# Altium schematic sheet Main.SchDot(Main)
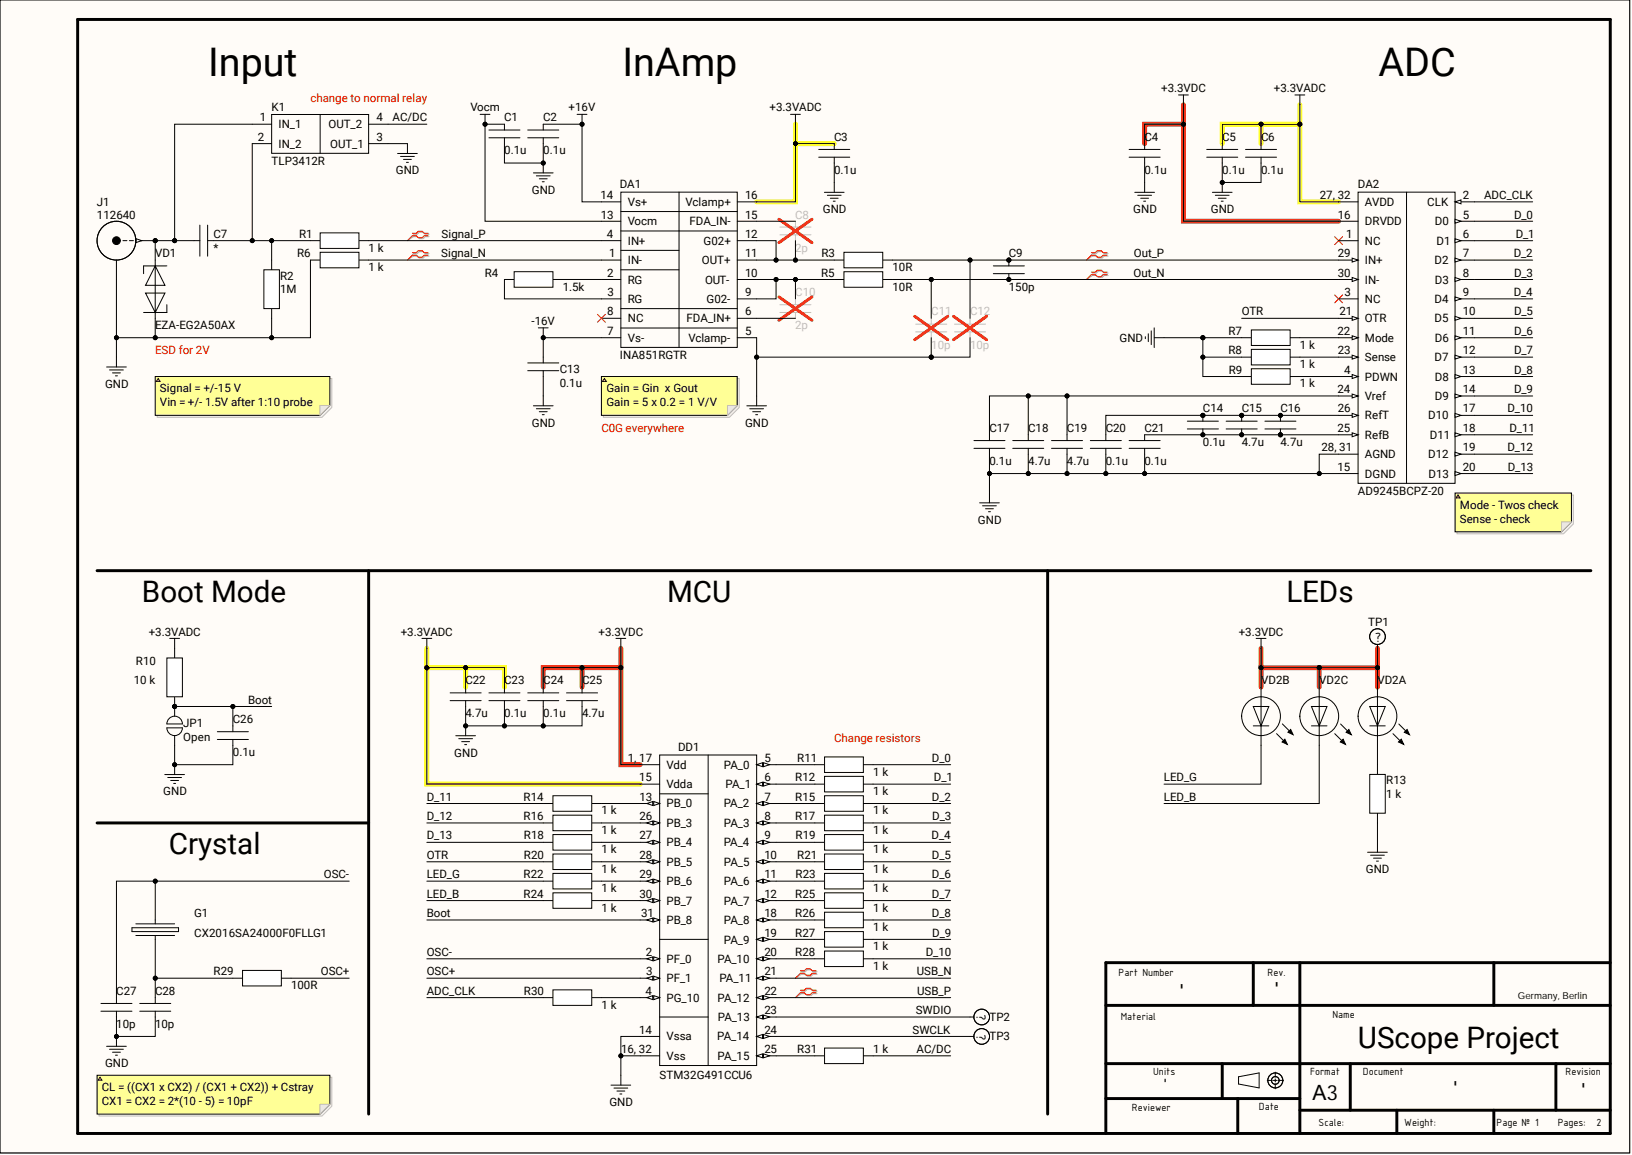
[diagram p0: Main.SchDot(Main)]

## Parts
C1  0.1u  [C0402]
C2  0.1u  [C0402]
C3  0.1u  [C0402]
C4  0.1u  [C0402]
C5  0.1u  [C0402]
C6  0.1u  [C0402]
C7  (no value)  [C0402]
C8  2p  [C0402]
C9  150p  [C0402]
C10  2p  [C0402]
C11  10p  [C0402]
C12  10p  [C0402]
C13  0.1u  [C0402]
C14  0.1u  [C0402]
C15  4.7u  [C0402]
C16  4.7u  [C0402]
C17  0.1u  [C0402]
C18  4.7u  [C0402]
C19  4.7u  [C0402]
C20  0.1u  [C0402]
C21  0.1u  [C0402]
C22  4.7u  [C0402]
C23  0.1u  [C0402]
C24  0.1u  [C0402]
C25  4.7u  [C0402]
C26  0.1u  [C0402]
C27  10p  [C0402]
C28  10p  [C0402]
DA1  INA851RGTR  [VQFN_16_INA851]
DA2  AD9245BCPZ-20  [LFCSP-32_AD9245]
DD1  STM32G491CCU6  [UFQFPN-32]
G1  CX2016SA24000F0FLLG1  [0806 (2016 metric)]
J1  112640  [112640]
JP1  Open  [Solder Bridge Jumper]
K1  TLP3412R  [SON-4]
R1  1 k  [R0402]
R2  1M  [R0402]
R3  10R  [R0402]
R4  1.5k  [R0402]
R5  10R  [R0402]
R6  1 k  [R0402]
R7  1 k  [R0402]
R8  1 k  [R0402]
R9  1 k  [R0402]
R10  10 k  [R0402]
R11  1 k  [R0402]
R12  1 k  [R0402]
R13  1 k  [R0402]
R14  1 k  [R0402]
R15  1 k  [R0402]
R16  1 k  [R0402]
R17  1 k  [R0402]
R18  1 k  [R0402]
R19  1 k  [R0402]
R20  1 k  [R0402]
R21  1 k  [R0402]
R22  1 k  [R0402]
R23  1 k  [R0402]
R24  1 k  [R0402]
R25  1 k  [R0402]
R26  1 k  [R0402]
R27  1 k  [R0402]
R28  1 k  [R0402]
R29  100R  [R0402]
R30  1 k  [R0402]
R31  1 k  [R0402]
TP1  5117  [Micro_test_pad]  (value not drawn)
TP2  5117  [Micro_test_pad]  (value not drawn)
TP3  5117  [Micro_test_pad]  (value not drawn)
VD1  EZA-EG2A50AX  [R0402]
VD2  150044M155260  [0404(RGB LED)]  (value not drawn)  (parts A,B,C)

## Nets
+3.3VADC: C3.2 C5.2 C6.2 C22.1 C23.1 DA1.16(Vclamp+) DA2.27, 32(AVDD) DD1.15(Vdda) R10.1
+3.3VDC: C4.2 C24.1 C25.1 DA2.16(DRVDD) DD1.1, 17(Vdd) TP1.1 VD2.1
+16V: C2.2 DA1.14(Vs+)
-16V: C13.2 DA1.7(Vs-)
GND: C1.1 C2.1 C3.1 C4.1 C5.1 C6.1 C11.2 C12.2 C13.1 C17.1 C18.2 C19.2 C20.1 C21.1 C22.2 C23.2 C24.2 C25.2 C26.2 C27.2 C28.2 DA1.5(Vclamp-) DA2.15(DGND) DA2.28, 31(AGND) DD1.14(Vssa) DD1.16, 32(Vss) J1.2 JP1.1 K1.3(OUT_1) R2.1 R6.2 R7.2 R8.2 R9.2 R13.2 VD1.2
Vocm: C1.2 DA1.13(Vocm)
AC/DC: K1.4(OUT_2) R31.1
ADC_CLK: DA2.2(CLK) R30.2
Boot: C26.1 DD1.31(PB_8) JP1.2 R10.2
D_0: DA2.5(D0) R11.1
D_1: DA2.6(D1) R12.1
D_2: DA2.7(D2) R15.1
D_3: DA2.8(D3) R17.1
D_4: DA2.9(D4) R19.1
D_5: DA2.10(D5) R21.1
D_6: DA2.11(D6) R23.1
D_7: DA2.12(D7) R25.1
D_8: DA2.13(D8) R26.1
D_9: DA2.14(D9) R27.1
D_10: DA2.17(D10) R28.1
D_11: DA2.18(D11) R14.2
D_12: DA2.19(D12) R16.2
D_13: DA2.20(D13) R18.2
LED_B: R24.2 VD2.4
LED_G: R22.2 VD2.3
OSC+: DD1.3(PF_1) R29.1
OSC-: C27.1 DD1.2(PF_0) G1.3
OTR: DA2.21(OTR) R20.2
Out_N: C9.2 C11.1 DA2.30(IN-) R5.1
Out_P: C9.1 C12.1 DA2.29(IN+) R3.1
Signal_N: DA1.1(IN-) R6.1
Signal_P: DA1.4(IN+) R1.1
SWCLK: DD1.24(PA_14) TP3.1
SWDIO: DD1.23(PA_13) TP2.1
USB_N: DD1.21(PA_11)
USB_P: DD1.22(PA_12)
NetC7_1: C7.1 J1.1 K1.1(IN_1) VD1.1
NetC7_2: C7.2 K1.2(IN_2) R1.2 R2.2
NetC8_1: C8.1 DA1.15(FDA_IN-)
NetC8_2: C8.2 DA1.11(OUT+) DA1.12(G02+) R3.2
NetC10_1: C10.1 DA1.9(G02-) DA1.10(OUT-) R5.2
NetC10_2: C10.2 DA1.6(FDA_IN+)
NetC14_1: C14.1 C15.2 C16.2 C21.2 DA2.25(RefB)
NetC14_2: C14.2 C15.1 C16.1 C20.2 DA2.26(RefT)
NetC17_2: C17.2 C18.1 C19.1 DA2.24(Vref)
NetC28_1: C28.1 G1.1 R29.2
NetDA1_2: DA1.2(RG) R4.2
NetDA1_3: DA1.3(RG) R4.1
NetDA2_4: DA2.4(PDWN) R9.1
NetDA2_22: DA2.22(Mode) R7.1
NetDA2_23: DA2.23(Sense) R8.1
NetDD1_4: DD1.4(PG_10) R30.1
NetDD1_5: DD1.5(PA_0) R11.2
NetDD1_6: DD1.6(PA_1) R12.2
NetDD1_7: DD1.7(PA_2) R15.2
NetDD1_8: DD1.8(PA_3) R17.2
NetDD1_9: DD1.9(PA_4) R19.2
NetDD1_10: DD1.10(PA_5) R21.2
NetDD1_11: DD1.11(PA_6) R23.2
NetDD1_12: DD1.12(PA_7) R25.2
NetDD1_13: DD1.13(PB_0) R14.1
NetDD1_18: DD1.18(PA_8) R26.2
NetDD1_19: DD1.19(PA_9) R27.2
NetDD1_20: DD1.20(PA_10) R28.2
NetDD1_25: DD1.25(PA_15) R31.2
NetDD1_26: DD1.26(PB_3) R16.1
NetDD1_27: DD1.27(PB_4) R18.1
NetDD1_28: DD1.28(PB_5) R20.1
NetDD1_29: DD1.29(PB_6) R22.1
NetDD1_30: DD1.30(PB_7) R24.1
NetR13_1: R13.1 VD2.2

Not connected: DA1 8(NC); DA2 1(NC) 3(NC)

## Library data of the parts
C1-C6 C13 C14 C17 C20 C21 C23 C24 C26 = MLCC: Multilayer Ceramic Capacitors MLCC - SMD/SMT 0.1 uF 50 VDC 20% 0402 X6S; Manufacturer: Murate; P/N: GRM155C81H104ME14D; Case: 0402; Component Kind: Standard; Component Type: MLCC; ComponentLink1Description: Link; ComponentLink2Description: Datasheet; Value: 0.1u; Library Name: Scheet.SchLib
C7 = MLCC: Cercap smd; Component Kind: Standard; ComponentLink1Description: Link; ComponentLink2Description: Datasheet; Library Name: Scheet.SchLib
C8 C10-C12 C27 C28 = MLCC: Multilayer Ceramic Capacitors MLCC - SMD/SMT 50V 10pF X7R 0402 10%; Manufacturer: KEMET; P/N: C0402C100K5RACTU; Case: 0402; Component Kind: Standard; Component Type: MLCC; ComponentLink1Description: Link; ComponentLink2Description: Datasheet; Library Name: Scheet.SchLib
  C8 C10: ComponentLink1URL: https://eu.mouser.com/ProductDetail/KEMET/C0402C100K5RACTU?qs=Mv7BduZupUgEdPvwNAxLRQ%3D%3D; ComponentLink2URL: https://eu.mouser.com/datasheet/2/447/KEM_C1002_X7R_SMD-3316098.pdf; Value: 2p
  C11 C12 C27: ComponentLink1URL: https://eu.mouser.com/ProductDetail/KEMET/C0402C100K5RACTU?qs=Mv7BduZupUgEdPvwNAxLRQ%3D%3D; ComponentLink2URL: https://eu.mouser.com/datasheet/2/447/KEM_C1002_X7R_SMD-3316098.pdf; Value: 10p
  C28: Value: 10p
C9 = MLCC: Multilayer Ceramic Capacitors MLCC - SMD/SMT 330 pF 50 VDC 5% 0402 X7R; Manufacturer: Murata; P/N: GRM155R71H331JA01D; Case: 0402; Component Kind: Standard; Component Type: MLCC; ComponentLink1Description: Link; ComponentLink1URL: https://eu.mouser.com/ProductDetail/Murata-Electronics/GRM155R71H331JA01D?qs=c4yvaafl%252BAzpmM4Bgmly0Q%3D%3D; ComponentLink2Description: Datasheet; ComponentLink2URL: https://eu.mouser.com/datasheet/2/281/1/GRM155R71H331JA01_01A-1984423.pdf; Value: 150p; Library Name: Scheet.SchLib
C15 C16 C18 C19 C22 C25 = MLCC: Multilayer Ceramic Capacitors MLCC - SMD/SMT 0402 X6S 4.7 F 20 10VDC AEC-Q200; Manufacturer: Murata; P/N: GRT155C81A475ME13D; Case: 0402; Component Kind: Standard; Component Type: MLCC; ComponentLink1Description: Link; ComponentLink2Description: Datasheet; Value: 4.7u; Library Name: Scheet.SchLib
DA1 = INA851RGTR: Instrumentation Amplifiers Low-noise (3.2 nV/vHz) high-speed (22 MHz) fully-differential instrumentation amp with OVP (+/-40 V) 16-VQFN -40 to 125; Manufacturer: Texas Instruments; Value: 3.2 nV/vHz. +-40V; Case: VQFN-16; Component Kind: Standard; Component Type: Instrumentation Amplifiers; ComponentLink1Description: Datasheet; ComponentLink1URL: https://www.ti.com/lit/ds/symlink/ina851.pdf?ts=1707581186124&ref_url=https%253A%252F%252Feu.mouser.com%252F; ComponentLink2Description: Link; ComponentLink2URL: https://eu.mouser.com/ProductDetail/Texas-Instruments/INA851RGTR?qs=Y0Uzf4wQF3kbGQkgMC0HWQ%3D%3D; P/N: INA851RGTR; Library Name: Scheet.SchLib
DA2 = AD9245: Analog to Digital Converters - ADC 14-Bit, 20 MSPS/40 MSPS/65 MSPS/80 MSPS 3 V A/D Converter; Manufacturer: Analog Devices Inc.; Value: 14 bit,  20 MS/s; Case: LFCSP-32; Component Kind: Standard; Component Type: Analog to Digital Converters - ADC; ComponentLink1Description: Datasheet; ComponentLink1URL: https://eu.mouser.com/datasheet/2/609/AD9245-3134626.pdf; ComponentLink2Description: Link; ComponentLink2URL: https://eu.mouser.com/ProductDetail/Analog-Devices/AD9245BCPZ-20?qs=%2FtpEQrCGXCyn%2FB%2F5xLQAJw%3D%3D; P/N: AD9245BCPZ-20; Library Name: Scheet.SchLib
DD1 = STM32G491CCU6: ARM Microcontrollers - MCU Mainstream Arm Cortex-M4+ MCU 170MHz with 256Kbytes of Flash memory; Manufacturer: STMicroelectronics; Value: 170 MHz, 256 kB,  4 x 12 bit; Case: UFQFPN-48; Component Kind: Standard; Component Type: ARM Microcontrollers - MCU; ComponentLink1Description: Datasheet; ComponentLink1URL: https://eu.mouser.com/datasheet/2/389/stm32g491cc-2079910.pdf; ComponentLink2Description: Link; ComponentLink2URL: https://eu.mouser.com/ProductDetail/STMicroelectronics/STM32G491CCU6?qs=eP2BKZSCXI7DW1LAe4ydBg%3D%3D; P/N: STM32G491CCU6; Library Name: Scheet.SchLib
G1 = CX2016SA24000F0FLLG1: Crystals 2.0mm x 1.6mm Crystal 24MHz 10pF +/-20ppm; Manufacturer: KYOCERA AVX; Case: 0806 (2016 metric); Component Kind: Standard; Component Type: Crystals; ComponentLink1Description: Datasheet; ComponentLink1URL: https://eu.mouser.com/datasheet/2/40/cx2016sa_e-2936404.pdf; ComponentLink2Description: Link; ComponentLink2URL: https://eu.mouser.com/ProductDetail/KYOCERA-AVX/CX2016SA24000F0FLLG1?qs=Imq1NPwxi762eftAlKVhMQ%3D%3D; P/N: CX2016SA24000F0FLLG1; Value: 24 MHz; Library Name: Scheet.SchLib
J1 = 112640: RF Connectors / Coaxial Connectors BNC PCB END LAUNCH 50 OHM RND BODY; Manufacturer: Amphenol; Value: 50 Ohms; Case: BNC; Component Kind: Standard; Component Type: Jack (Socket); ComponentLink1Description: Datasheet; ComponentLink1URL: https://eu.mouser.com/datasheet/2/18/1/amph_s_a0003617014_1-2261107.pdf; ComponentLink2Description: Link; ComponentLink2URL: https://eu.mouser.com/ProductDetail/Amphenol-RF/112640?qs=g6wJ%252BjiQWOckvE%2FP9L4wAw%3D%3D; P/N: 112640; Library Name: Scheet.SchLib
JP1 = (no symbol name): Solder Bridge Jumper; Component Kind: Standard; ComponentLink1Description: Datasheet; ComponentLink2Description: Link; Library Name: Others.SchLib; P/N: Open
K1 = TLP3412R: Solid State Relays - PCB Mount; Manufacturer: Toshiba; Value: SON-4; Case: 0402; Component Kind: Standard; Component Type: TVS Diodes / ESD Suppressors; ComponentLink1Description: Datasheet; ComponentLink1URL: https://eu.mouser.com/datasheet/2/408/TLP3412R_datasheet_en_20230525-1074906.pdf; ComponentLink2Description: Link; ComponentLink2URL: https://eu.mouser.com/ProductDetail/Toshiba/TLP3412RTPF?qs=hicmRmzGcNTvmIS5mxQOPQ%3D%3D; P/N: TLP3412R; Library Name: Scheet.SchLib
R1 R6-R9 R11-R28 R30 R31 = SMD Resistor: Thick Film Resistors - SMD 0402 1K0 0.5%; Manufacturer: Kamaya; P/N: RGC1/16SC1001DTH; Case: 0402; Component Kind: Standard; ComponentLink1Description: Datasheet; ComponentLink2Description: Link; Value: 1 k; Library Name: Scheet.SchLib
  R14 R16 R18 R19 R25 R28: ComponentLink1URL: https://eu.mouser.com/datasheet/2/315/AOA0000C334-1314047.pdf; ComponentLink2URL: https://eu.mouser.com/ProductDetail/Panasonic/ERJ-U02J471X?qs=sGAEpiMZZMvdGkrng054txgTq5MjvbWtfBwA8U7MccU%3D
R2 = SMD Resistor: Res SMD; Component Kind: Standard; ComponentLink1Description: Datasheet; ComponentLink2Description: Link; Value: 1M; Library Name: Scheet.SchLib
R3 R5 = SMD Resistor: Thick Film Resistors - SMD 10 Ohms 62.5 mW 0402 1%; Manufacturer: YAGEO; P/N: RE0402FRE0710RL; Case: 0402; Component Kind: Standard; ComponentLink1Description: Datasheet; ComponentLink2Description: Link; Value: 10R; Library Name: Scheet.SchLib
  R3: ComponentLink1URL: https://eu.mouser.com/datasheet/2/447/PYu_RE_105_RoHS_L_6-3003059.pdf; ComponentLink2URL: https://eu.mouser.com/ProductDetail/YAGEO/RE0402FRE0710RL?qs=k2KEx2DUIRTYXuhFDRdWcQ%3D%3D
R4 = SMD Resistor: Thick Film Resistors - SMD 0402 1K0 0.5%; Case: 0402; Component Kind: Standard; ComponentLink1Description: Datasheet; ComponentLink1URL: https://eu.mouser.com/datasheet/2/210/kamaya_products_RGC__english_20170424_101024-1651573.pdf; ComponentLink2Description: Link; ComponentLink2URL: https://eu.mouser.com/ProductDetail/Kamaya/RGC1-16SC1001DTH?qs=KAq9QXcE8HAz3iV8i32W5A%3D%3D; Value: 1.5k; Library Name: Scheet.SchLib
R10 = SMD Resistor: Thick Film Resistors - SMD 0402 10Kohms 0.5%AEC-Q200; Manufacturer: Panasonic; P/N: ERJ-2RHD103X; Case: 0402; Component Kind: Standard; ComponentLink1Description: Datasheet; ComponentLink1URL: https://eu.mouser.com/datasheet/2/315/AOA0000C304-1149620.pdf; ComponentLink2Description: Link; ComponentLink2URL: https://eu.mouser.com/ProductDetail/Panasonic/ERJ-2RKF2202X?qs=sGAEpiMZZMvdGkrng054t8AJgcdMkx7xGCv6QlnvaAM%3D; Value: 10 k; Library Name: Scheet.SchLib
R29 = SMD Resistor: Thick Film Resistors 0402 100ohms 5% AEC-Q200; Manufacturer: Panasonic; P/N: ERJ-2GEJ101X; Case: 0402; Component Kind: Standard; ComponentLink1Description: Datasheet; ComponentLink1URL: https://eu.mouser.com/datasheet/2/315/AOA0000C301-1488782.pdf; ComponentLink2Description: Link; ComponentLink2URL: https://eu.mouser.com/ProductDetail/Panasonic/ERJ-2GEJ101X?qs=sGAEpiMZZMvdGkrng054t8ugkoYZivkgDJYBiBKVgh4%3D; Value: 100R; Library Name: Scheet.SchLib
TP1-TP3 = (no symbol name): PCB TEST POINT BLUE; Manufacturer: Keystone Electronics; Value: 36-5117; Case: THT; Component Kind: Standard; Component Type: Test point; ComponentLink1Description: Datasheet; ComponentLink1URL: https://ru.mouser.com/datasheet/2/215/5115_5119-744073.pdf; ComponentLink2Description: Link; ComponentLink2URL: https://ru.mouser.com/ProductDetail/Keystone-Electronics/5117?qs=%2Fha2pyFadujSuVybhyFc6oqj%252BXCGMBBx2uPmIz4OBqU%3D; Library Name: Others.SchLib; P/N: 5117
VD1 = EZA-EG2A50AX: TVS Diodes / ESD Suppressors Dataline Protection PP; Manufacturer: Panasonic; Value: 1 channel, Bidirectional, 100V; Case: 0402; Component Kind: Standard; Component Type: TVS Diodes / ESD Suppressors; ComponentLink1Description: Datasheet; ComponentLink1URL: https://eu.mouser.com/datasheet/2/315/AWD0000C13-1131263.pdf; ComponentLink2Description: Link; ComponentLink2URL: https://eu.mouser.com/ProductDetail/Panasonic/EZA-EG2A50AX?qs=sGAEpiMZZMsItbzKdwyIez%252BvwGoF%252BBrnhQ8KlbijrwA%3D; P/N: EZA-EG2A50AX; Library Name: Scheet.SchLib
VD2 = 150044M155260: Standard LEDs - SMD WL-SFCC SMT LED Full-color Chip; Manufacturer: Wurth Elektronik; Value: RGB LEDs; Case: 0404; Component Kind: Standard; Component Type: Standard LEDs - SMD; ComponentLink1Description: Datasheet; ComponentLink1URL: https://www.we-online.com/components/products/datasheet/150044M155260.pdf; ComponentLink2Description: Link; ComponentLink2URL: https://eu.mouser.com/ProductDetail/Wurth-Elektronik/150044M155260?qs=u16ybLDytRba39Og9E2ywA%3D%3D; P/N: 150044M155260; Library Name: Scheet.SchLib
